# Altium schematic sheet [09] - Mechanical.SchDoc
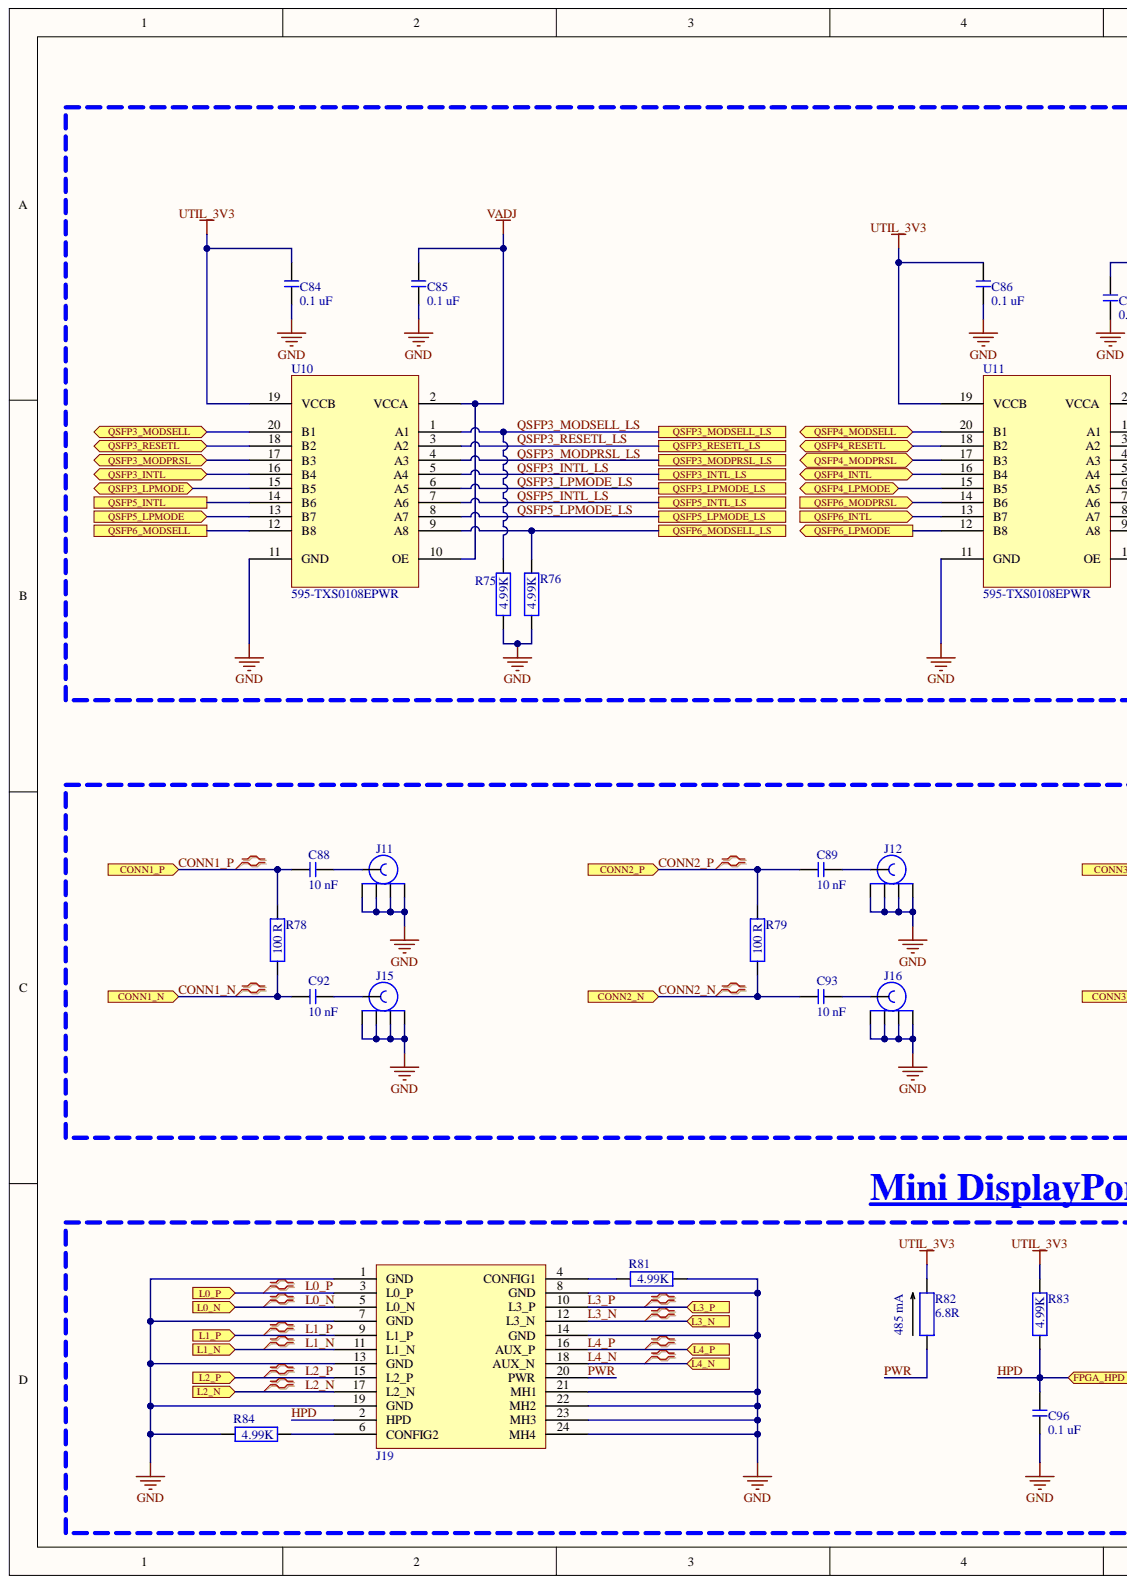
[diagram p0: [09] - Mechanical.SchDoc, left]
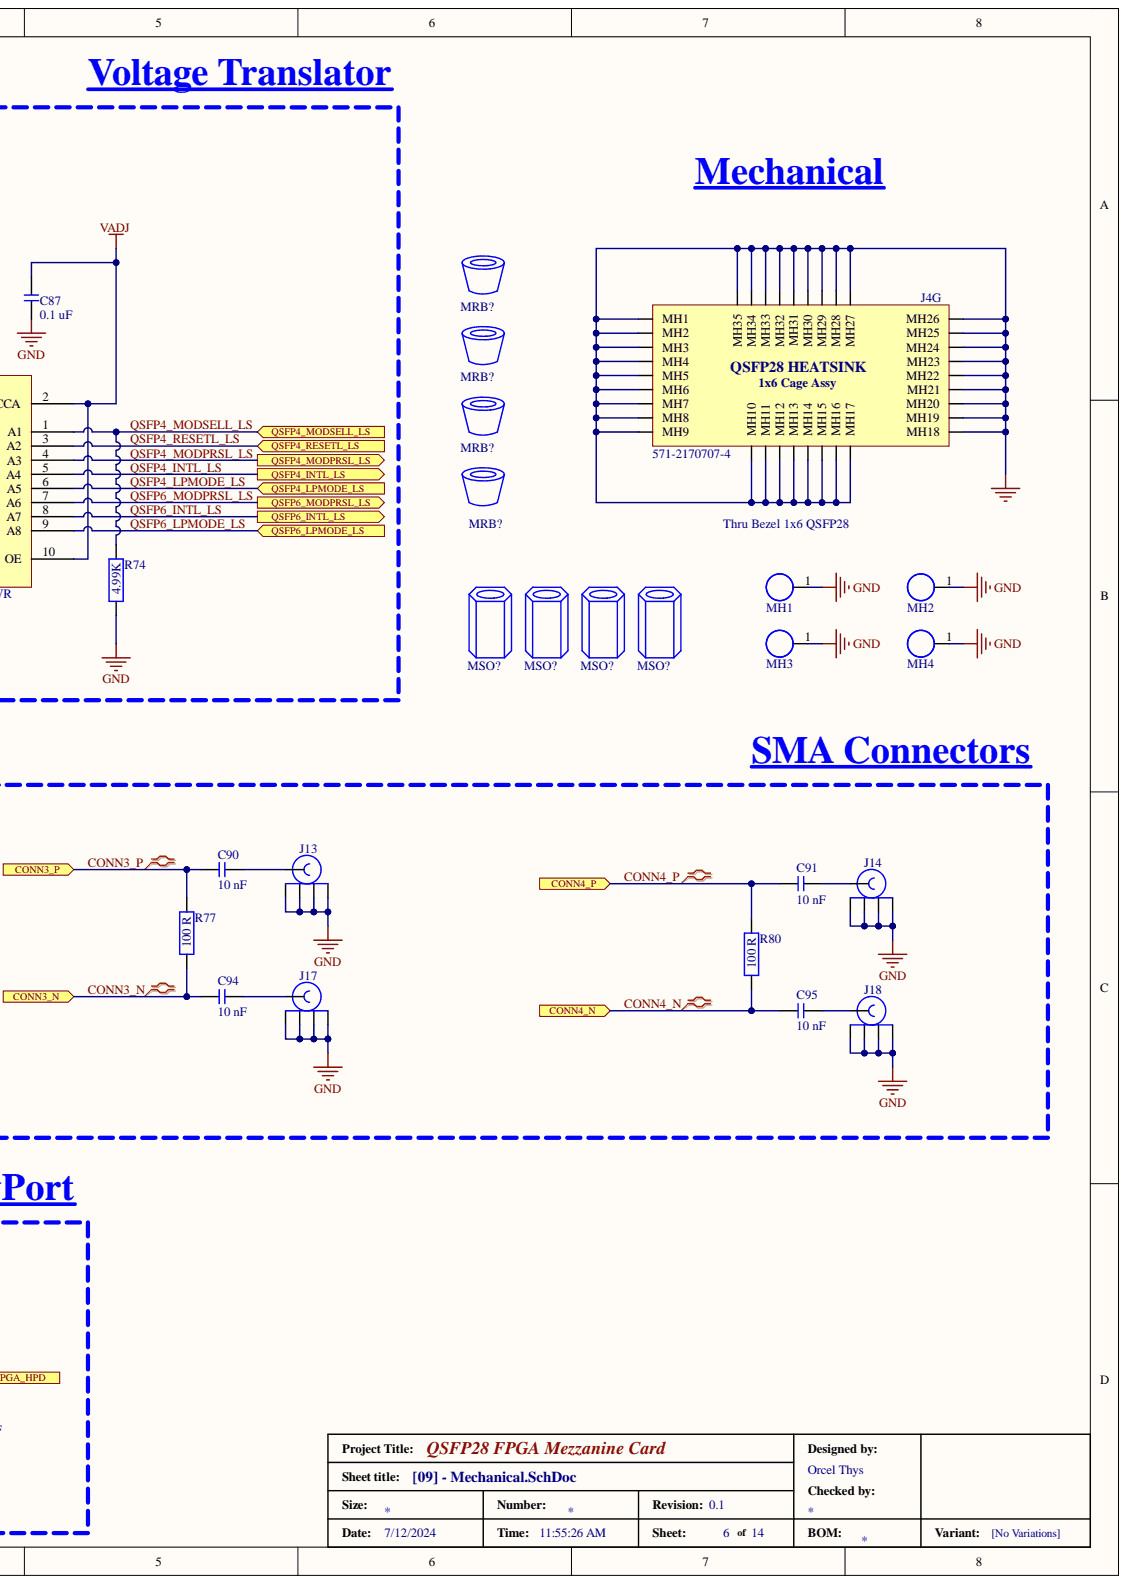
[diagram p1: [09] - Mechanical.SchDoc, right]

## Parts
C84  0.1 uF  [C0402]
C85  0.1 uF  [C0402]
C86  0.1 uF  [C0402]
C87  0.1 uF  [C0402]
C88  10 nF  [C0402]
C89  10 nF  [C0402]
C90  10 nF  [C0402]
C91  10 nF  [C0402]
C92  10 nF  [C0402]
C93  10 nF  [C0402]
C94  10 nF  [C0402]
C95  10 nF  [C0402]
C96  0.1 uF  [C0402]
J4  Thru Bezel 1x6 QSFP28  Supplier Part Number 1: 571-2170707-4  [TE_2170707-4]  (part G)
J11  SMA-J-P-H_ST-EM1  [SAMTEC_SMA-J-P-H-ST-EM1]  (value not drawn)
J12  SMA-J-P-H_ST-EM1  [SAMTEC_SMA-J-P-H-ST-EM1]  (value not drawn)
J13  SMA-J-P-H_ST-EM1  [SAMTEC_SMA-J-P-H-ST-EM1]  (value not drawn)
J14  SMA-J-P-H_ST-EM1  [SAMTEC_SMA-J-P-H-ST-EM1]  (value not drawn)
J15  SMA-J-P-H_ST-EM1  [SAMTEC_SMA-J-P-H-ST-EM1]  (value not drawn)
J16  SMA-J-P-H_ST-EM1  [SAMTEC_SMA-J-P-H-ST-EM1]  (value not drawn)
J17  SMA-J-P-H_ST-EM1  [SAMTEC_SMA-J-P-H-ST-EM1]  (value not drawn)
J18  SMA-J-P-H_ST-EM1  [SAMTEC_SMA-J-P-H-ST-EM1]  (value not drawn)
J19  Mini DisplayPort  [MiniDP_2129320-3]
MH1  Mountimg Hole  [MH_250H125]  (value not drawn)
MH2  Mountimg Hole  [MH_250H125]  (value not drawn)
MH3  Mountimg Hole  [MH_250H125]  (value not drawn)
MH4  Mountimg Hole  [MH_250H125]  (value not drawn)
R74  4.99K  [R0402]
R75  4.99K  [R0402]
R76  4.99K  [R0402]
R77  100 R  [R0402]
R78  100 R  [R0402]
R79  100 R  [R0402]
R80  100 R  [R0402]
R81  4.99K  [R0402]
R82  6.8R  [RESC6331X70N]
R83  4.99K  [R0402]
R84  4.99K  [R0402]
U10  Supplier Part Number 1: 595-TXS0108EPWR  [SOP_65P640X120-20N]  (hidden comment: 8-bit voltage translator)
U11  Supplier Part Number 1: 595-TXS0108EPWR  [SOP_65P640X120-20N]  (hidden comment: 8-bit voltage translator)

## Ports
CONN1_N, CONN1_P, CONN2_N, CONN2_P, CONN3_N, CONN3_P, CONN4_N, CONN4_P, FPGA_HPD, L0_N, L0_P, L1_N, L1_P, L2_N, L2_P, L3_N, L3_P, L4_N, L4_P, QSFP3_INTL, QSFP3_INTL_LS, QSFP3_LPMODE, QSFP3_LPMODE_LS, QSFP3_MODPRSL, QSFP3_MODPRSL_LS, QSFP3_MODSELL, QSFP3_MODSELL_LS, QSFP3_RESETL, QSFP3_RESETL_LS, QSFP4_INTL, QSFP4_INTL_LS, QSFP4_LPMODE, QSFP4_LPMODE_LS, QSFP4_MODPRSL, QSFP4_MODPRSL_LS, QSFP4_MODSELL, QSFP4_MODSELL_LS, QSFP4_RESETL, QSFP4_RESETL_LS, QSFP5_INTL, QSFP5_INTL_LS, QSFP5_LPMODE, QSFP5_LPMODE_LS, QSFP6_INTL, QSFP6_INTL_LS, QSFP6_LPMODE, QSFP6_LPMODE_LS, QSFP6_MODPRSL, QSFP6_MODPRSL_LS, QSFP6_MODSELL, QSFP6_MODSELL_LS

## Nets
GND: C84.1 C85.1 C86.1 C87.1 C96.1 J4.MH1 J4.MH2 J4.MH3 J4.MH4 J4.MH5 J4.MH6 J4.MH7 J4.MH8 J4.MH9 J4.MH10 J4.MH11 J4.MH12 J4.MH13 J4.MH14 J4.MH15 J4.MH16 J4.MH17 J4.MH18 J4.MH19 J4.MH20 J4.MH21 J4.MH22 J4.MH23 J4.MH24 J4.MH25 J4.MH26 J4.MH27 J4.MH28 J4.MH29 J4.MH30 J4.MH31 J4.MH32 J4.MH33 J4.MH34 J4.MH35 J11.2 J11.3 J11.4 J11.5 J12.2 J12.3 J12.4 J12.5 J13.2 J13.3 J13.4 J13.5 J14.2 J14.3 J14.4 J14.5 J15.2 J15.3 J15.4 J15.5 J16.2 J16.3 J16.4 J16.5 J17.2 J17.3 J17.4 J17.5 J18.2 J18.3 J18.4 J18.5 J19.1(GND) J19.7(GND) J19.8(GND) J19.13(GND) J19.14(GND) J19.19(GND) J19.21(MH1) J19.22(MH2) J19.23(MH3) J19.24(MH4) MH1.1 MH2.1 MH3.1 MH4.1 R74.1 R75.1 R76.1 R81.1 R84.1 U10.11(GND) U11.11(GND)
UTIL_3V3: C84.2 C86.2 R82.2 R83.2 U10.19(VCCB) U11.19(VCCB)
VADJ: C85.2 C87.2 U10.2(VCCA) U10.10(OE) U11.2(VCCA) U11.10(OE)
CONN1_N: C92.1 R78.1 ; port CONN1_N
CONN1_P: C88.1 R78.2 ; port CONN1_P
CONN2_N: C93.1 R79.1 ; port CONN2_N
CONN2_P: C89.1 R79.2 ; port CONN2_P
CONN3_N: C94.1 R77.1 ; port CONN3_N
CONN3_P: C90.2 R77.2 ; port CONN3_P
CONN4_N: C95.1 R80.1 ; port CONN4_N
CONN4_P: C91.1 R80.2 ; port CONN4_P
HPD: C96.2 J19.2(HPD) R83.1 ; port FPGA_HPD
L0_N: J19.5(L0_N) ; port L0_N
L0_P: J19.3(L0_P) ; port L0_P
L1_N: J19.11(L1_N) ; port L1_N
L1_P: J19.9(L1_P) ; port L1_P
L2_N: J19.17(L2_N) ; port L2_N
L2_P: J19.15(L2_P) ; port L2_P
L3_N: J19.12(L3_N) ; port L3_N
L3_P: J19.10(L3_P) ; port L3_P
L4_N: J19.18(AUX_N) ; port L4_N
L4_P: J19.16(AUX_P) ; port L4_P
PWR: J19.20(PWR) R82.1
QSFP3_INTL: U10.16(B4) ; port QSFP3_INTL
QSFP3_INTL_LS: U10.5(A4) ; port QSFP3_INTL_LS
QSFP3_LPMODE: U10.15(B5) ; port QSFP3_LPMODE
QSFP3_LPMODE_LS: U10.6(A5) ; port QSFP3_LPMODE_LS
QSFP3_MODPRSL: U10.17(B3) ; port QSFP3_MODPRSL
QSFP3_MODPRSL_LS: U10.4(A3) ; port QSFP3_MODPRSL_LS
QSFP3_MODSELL: U10.20(B1) ; port QSFP3_MODSELL
QSFP3_MODSELL_LS: R75.2 U10.1(A1) ; port QSFP3_MODSELL_LS
QSFP3_RESETL: U10.18(B2) ; port QSFP3_RESETL
QSFP3_RESETL_LS: U10.3(A2) ; port QSFP3_RESETL_LS
QSFP4_INTL: U11.16(B4) ; port QSFP4_INTL
QSFP4_INTL_LS: U11.5(A4) ; port QSFP4_INTL_LS
QSFP4_LPMODE: U11.15(B5) ; port QSFP4_LPMODE
QSFP4_LPMODE_LS: U11.6(A5) ; port QSFP4_LPMODE_LS
QSFP4_MODPRSL: U11.17(B3) ; port QSFP4_MODPRSL
QSFP4_MODPRSL_LS: U11.4(A3) ; port QSFP4_MODPRSL_LS
QSFP4_MODSELL: U11.20(B1) ; port QSFP4_MODSELL
QSFP4_MODSELL_LS: R74.2 U11.1(A1) ; port QSFP4_MODSELL_LS
QSFP4_RESETL: U11.18(B2) ; port QSFP4_RESETL
QSFP4_RESETL_LS: U11.3(A2) ; port QSFP4_RESETL_LS
QSFP5_INTL: U10.14(B6) ; port QSFP5_INTL
QSFP5_INTL_LS: U10.7(A6) ; port QSFP5_INTL_LS
QSFP5_LPMODE: U10.13(B7) ; port QSFP5_LPMODE
QSFP5_LPMODE_LS: U10.8(A7) ; port QSFP5_LPMODE_LS
QSFP6_INTL: U11.13(B7) ; port QSFP6_INTL
QSFP6_INTL_LS: U11.8(A7) ; port QSFP6_INTL_LS
QSFP6_LPMODE: U11.12(B8) ; port QSFP6_LPMODE
QSFP6_LPMODE_LS: U11.9(A8) ; port QSFP6_LPMODE_LS
QSFP6_MODPRSL: U11.14(B6) ; port QSFP6_MODPRSL
QSFP6_MODPRSL_LS: U11.7(A6) ; port QSFP6_MODPRSL_LS
QSFP6_MODSELL: U10.12(B8) ; port QSFP6_MODSELL
QSFP6_MODSELL_LS: R76.2 U10.9(A8) ; port QSFP6_MODSELL_LS
NetC88_2: C88.2 J11.1
NetC89_2: C89.2 J12.1
NetC90_1: C90.1 J13.1
NetC91_2: C91.2 J14.1
NetC92_2: C92.2 J15.1
NetC93_2: C93.2 J16.1
NetC94_2: C94.2 J17.1
NetC95_2: C95.2 J18.1
NetJ19_4: J19.4(CONFIG1) R81.2
NetJ19_6: J19.6(CONFIG2) R84.2

## Library data of the parts
C84-C87 C96 = UMK105B7104KV-FR: Cap 0402 0.1 uF 50 V ±10 % Tolerance X7R Surface Mount Multilayer Ceramic Capacitor; Manufacturer: Taiyo Yuden; Capacitance: 100nF; Tolerance: 10%; Voltage Rating: 50V; Case Code (Imperial): 0402; Case Code (Metric): 1005; Case/Package: 0402; Category: Ceramic Capacitors; Component Kind: Standard; ComponentLink1Description: https://datasheet.ciiva.com/24356/619umk105b7104kv-fr-24356845.pdf?src-supplier=Onlinecomponents.com; ComponentLink1URL: https://datasheet.ciiva.com/24356/619umk105b7104kv-fr-24356845.pdf?src-supplier=Onlinecomponents.com; ComponentLink2Description: https://datasheet.ciiva.com/30180/52umk105b7104kv-fr-30180098.pdf?src-supplier=Onlinecomponents.com; ComponentLink2URL: https://datasheet.ciiva.com/30180/52umk105b7104kv-fr-30180098.pdf?src-supplier=Onlinecomponents.com; ComponentLink3Description: https://datasheet.ciiva.com/18697/mlcc-all-e-18697452.pdf?src-supplier=Digi-Key; ComponentLink3URL: https://datasheet.ciiva.com/18697/mlcc-all-e-18697452.pdf?src-supplier=Digi-Key; ComponentLink4Description: https://datasheet.ciiva.com/18697/mlcc-all-e-18697451.pdf?src-supplier=Digi-Key; ComponentLink4URL: https://datasheet.ciiva.com/18697/mlcc-all-e-18697451.pdf?src-supplier=Digi-Key; ComponentLink5Description: https://datasheet.ciiva.com/18697/mlcc-all-e-18697453.pdf?src-supplier=Digi-Key; ComponentLink5URL: https://datasheet.ciiva.com/18697/mlcc-all-e-18697453.pdf?src-supplier=Digi-Key; Dielectric: X7R; Halogen Free: Halogen Free; Height: 0.5mm; Lead Free: Lead Free; Length: 0.039inch; Manufacturer Part Number: UMK105B7104KV-FR; Max Operating Temperature: 125°C; Min Operating Temperature: -55°C; Mount: Surface Mount; Package Quantity: 1; Packaging: Tape and Reel; Pricing 1: 10000=0.016 (EUR); RoHS: Yes; RoHS Compliant: Yes; Series: M; Stock 1: 60000; Supplier 1: Rs; Supplier Part Number 1: 1782869; Termination: SMD/SMT; Thickness: 0.022inch; Voltage Rating (DC): 50V; Width: 0.02inch; Library Name: QSFP28_FMC_lib.SchLib
C88-C95 = C0402C103K3RACTU: Cap Multilayer Ceramic Capacitors MLCC - SMD/SMT 25volts 10000pF X7R 10%; Manufacturer: KEMET; Capacitance: 10nF; Dissipation Factor: 3.5%; Voltage Rating: 25V; Case Code (Imperial): 0402; Case Code (Metric): 1005; Case/Package: 0402; Category: Ceramic Capacitors; Component Kind: Standard; ComponentLink1Description: https://datasheet.ciiva.com/7747/kem-c1002-x7r-smd-7747612.pdf?src-supplier=Element14; ComponentLink1URL: https://datasheet.ciiva.com/7747/kem-c1002-x7r-smd-7747612.pdf?src-supplier=Element14; ComponentLink2Description: https://datasheet.ciiva.com/45846/docfinderpartnumberc0402c102k5ractu-45846917.pdf?src-supplier=Onlinecomponents.com; ComponentLink2URL: https://datasheet.ciiva.com/45846/docfinderpartnumberc0402c102k5ractu-45846917.pdf?src-supplier=Onlinecomponents.com; ComponentLink3Description: https://datasheet.ciiva.com/18192/getdatasheetpartid-330802-18192396.pdf?src-supplier=Verical; ComponentLink3URL: https://datasheet.ciiva.com/18192/getdatasheetpartid-330802-18192396.pdf?src-supplier=Verical; Construction: Flat; Depth: 0.5mm; Dielectric: X7R; Height: 0.5mm; Lead Pitch: 0.3mm; Length: 1mm; Manufacturer Part Number: C0402C103K3RACTU; Max Operating Temperature: 125°C; Min Operating Temperature: -55°C; Mount: Surface Mount; Number of Pins: 2; Package Quantity: 10000; Packaging: Tape and Reel; Pricing 1: 1=0.1, 10=0.018, 50=0.018, 100=0.008, 1000=0.006, 10000=0.003 (USD); Radiation Hardening: No; Reach SVHC Compliant: No; RoHS: Yes; RoHS Compliant: Yes; Series: SMD Comm X7R; Stock 1: 1004059; Supplier 1: Mouser; Supplier Part Number 1: 80-C0402C103K3R; Termination: SMD/SMT; Tolerance: 10%; Voltage Rating (DC): 25V; Width: 0.5mm; Library Name: QSFP28_FMC_lib.SchLib
J4 = 2170707-4: Cage Assy, 1X6, QSFP28, Spring, Hs; Manufacturer: TE Connectivity AMP; Category: Connectors; Component Kind: Standard; ComponentLink1Description: https://www.te.com/commerce/DocumentDelivery/DDEController?Action=srchrtrv&DocNm=2170707&DocType=Customer+Drawing&DocLang=English&src-supplier=Digi-Key; ComponentLink1URL: https://www.te.com/commerce/DocumentDelivery/DDEController?Action=srchrtrv&DocNm=2170707&DocType=Customer+Drawing&DocLang=English&src-supplier=Digi-Key; Manufacturer Part Number: 2170707-4; Pricing 1: 1=80.77, 10=80.77, 50=71.27, 100=70.28, 1000=67.22, 10000=67.22 (USD); RoHS: Yes; Stock 1: 60; Supplier 1: Mouser; Library Name: QSFP28_FMC_lib.SchLib
J11-J18 = SMA-J-P-H-ST-EM1: RF Connectors / Coaxial Connectors 6 GHz, 50 Ohm SMA Jack or Plug, Cable Connector; Manufacturer: Samtec; Body Material: Brass; Body Plating: Gold; Dielectric Material: PTFE; Frequency: 20GHz; Impedance: 50R; Number of Contacts: 1NUMBER; Number of Ports: 1; Voltage Rating: 335V; Case/Package: SMA; Category: RF / Coaxial Connectors; Center Contact Plating: Gold; Component Kind: Standard; ComponentLink1Description: https://datasheet.ciiva.com/646/sma-j-p-x-st-em1-mkt-646053.pdf?src-supplier=Digikey; ComponentLink1URL: https://datasheet.ciiva.com/646/sma-j-p-x-st-em1-mkt-646053.pdf?src-supplier=Digikey; Connector Type: SMA; Contact Material: Copper; Contact Plating: Gold; Depth: 13.33mm; Fastening Type: Threaded; Housing Color: Gold; Insulation Material: Polytetrafluoroethylene; Length: 6.35mm; Manufacturer Part Number: SMA-J-P-H-ST-EM1; Max Frequency: 20GHz; Max Operating Temperature: 125°C; Min Operating Temperature: -65°C; Mount: Edge,Board; Orientation: Straight; Package Quantity: 1; Packaging: Tray; Pricing 1: 1=5.76, 10=4.18, 50=3.98, 100=3.83, 500=2.76 (EUR); Radiation Hardening: No; RoHS: Yes; RoHS Compliant: Yes; Series: -; Stock 1: 33; Supplier 1: Rs; Supplier Part Number 1: 1592304; Termination: Solder; Library Name: QSFP28_FMC_lib.SchLib
J19 = 47272-0029: Conn Display Port RCP 20 POS 1mm Solder RA SMD 20 Terminal 1 Port T/R; Manufacturer: Molex; Category: Audio / Video Connectors; Component Kind: Standard; ComponentLink1Description: http://www.molex.com/pdm_docs/sd/472720029_sd.pdf?src-supplier=Mouser; ComponentLink1URL: http://www.molex.com/pdm_docs/sd/472720029_sd.pdf?src-supplier=Mouser; Manufacturer Part Number: 47272-0029; Pricing 1: 1=5.3, 10=4.34, 100=3.83, 250=3.35, 500=3.26, 1000=2.77 (EUR); RoHS: Yes; Stock 1: 941; Supplier 1: Farnell; Supplier Part Number 1: 3911167; Library Name: QSFP28_FMC_lib.SchLib
MH1-MH4 = MH_250H125: MOUNTING HOLE, 125 HOLE 250 PAD; Component Kind: Standard; Library Name: QSFP28_FMC_lib.SchLib
R74-R76 R81 R83 R84 = ERJ-2RKF4991X: RES SMD 4.99K OHM 1% 1/10W 0402; Manufacturer: Panasonic; Max Power Dissipation: 100mW; Resistance: 4.99kR; Temperature Coefficient: 100ppm/°C; Voltage Rating: 50V; Case Code (Imperial): 0402; Case Code (Metric): 1005; Case/Package: 0402; Category: Chip SMD Resistors; Component Kind: Standard; ComponentLink1Description: https://datasheet.ciiva.com/46388/426256-46388311.pdf?src-supplier=Element14; ComponentLink1URL: https://datasheet.ciiva.com/46388/426256-46388311.pdf?src-supplier=Element14; ComponentLink2Description: https://datasheet.ciiva.com/46376/426256-46376955.pdf?src-supplier=Element14; ComponentLink2URL: https://datasheet.ciiva.com/46376/426256-46376955.pdf?src-supplier=Element14; ComponentLink3Description: https://datasheet.ciiva.com/22749/1678782-22749654.pdf?src-supplier=Element14; ComponentLink3URL: https://datasheet.ciiva.com/22749/1678782-22749654.pdf?src-supplier=Element14; ComponentLink4Description: https://datasheet.ciiva.com/24158/aoa0000ce2-24158871.pdf?src-supplier=Mouser; ComponentLink4URL: https://datasheet.ciiva.com/24158/aoa0000ce2-24158871.pdf?src-supplier=Mouser; ComponentLink5Description: https://datasheet.ciiva.com/16775/70260748-16775018.pdf?src-supplier=Allied+Electronics; ComponentLink5URL: https://datasheet.ciiva.com/16775/70260748-16775018.pdf?src-supplier=Allied+Electronics; ComponentLink6Description: https://datasheet.ciiva.com/16773/70260748-16773110.pdf?src-supplier=Allied+Electronics; ComponentLink6URL: https://datasheet.ciiva.com/16773/70260748-16773110.pdf?src-supplier=Allied+Electronics; ComponentLink7Description: https://datasheet.ciiva.com/16199/aoa0000ce2-16199546.pdf?src-supplier=Future+Electronics; ComponentLink7URL: https://datasheet.ciiva.com/16199/aoa0000ce2-16199546.pdf?src-supplier=Future+Electronics; Construction: Rectangular; Contact Plating: Tin; Depth: 0.5mm; Features: Automotive AEC-Q200; Height: 0.016inch; Lead Free: Lead Free; Length: 1mm; Manufacturer Part Number: ERJ-2RKF4991X; Max Operating Temperature: 155°C; Military Standard: Not; Min Operating Temperature: -55°C; Mount: Surface Mount; Number of Terminations: 2; Package Quantity: 1; Packaging: Tape and Reel; Power Rating: 100mW; Pricing 1: 1=0.1, 10=0.018, 50=0.018, 100=0.008, 1000=0.006, 10000=0.004 (USD); Radiation Hardening: No; Reach SVHC Compliant: No; Resistance Tolerance: 1%; Resistor Type: Thick Film; RoHS: Yes; RoHS Compliant: Yes; Series: ERJ; Stock 1: 1218727; Supplier 1: Mouser; Supplier Part Number 1: 667-ERJ-2RKF4991X; Technology: THICK FILM; Termination: SMD/SMT; Tolerance: 1%; Voltage Rating (DC): 50V; Weight: 2.8E-05oz; Width: 0.5mm; Working Voltage: 50V; Library Name: QSFP28_FMC_lib.SchLib
R77-R80 = ERJ-2RKF1000X: RES Thick Film 0402 100 Ohm 1% 1/10W 100ppm/C Molded SMD Punched Carrier T/R; Manufacturer: Panasonic; Max Power Dissipation: 100mW; Resistance: 100R; Temperature Coefficient: 100ppm/°C; Voltage Rating: 50V; Case Code (Imperial): 0402; Case Code (Metric): 1005; Case/Package: 0402; Category: Chip SMD Resistors; Component Kind: Standard; ComponentLink1Description: https://datasheet.ciiva.com/27056/2007311-27056035.pdf?src-supplier=Jameco; ComponentLink1URL: https://datasheet.ciiva.com/27056/2007311-27056035.pdf?src-supplier=Jameco; ComponentLink2Description: https://datasheet.ciiva.com/11821/0900766b80f80784-11821173.pdf?src-supplier=Rs; ComponentLink2URL: https://datasheet.ciiva.com/11821/0900766b80f80784-11821173.pdf?src-supplier=Rs; ComponentLink3Description: https://datasheet.ciiva.com/7830/426256-7830973.pdf?src-supplier=Element14; ComponentLink3URL: https://datasheet.ciiva.com/7830/426256-7830973.pdf?src-supplier=Element14; ComponentLink4Description: https://datasheet.ciiva.com/43467/1678782-43467223.pdf?src-supplier=Farnell; ComponentLink4URL: https://datasheet.ciiva.com/43467/1678782-43467223.pdf?src-supplier=Farnell; ComponentLink5Description: https://datasheet.ciiva.com/28514/1678782-28514175.pdf?src-supplier=Element14; ComponentLink5URL: https://datasheet.ciiva.com/28514/1678782-28514175.pdf?src-supplier=Element14; ComponentLink6Description: https://datasheet.ciiva.com/46372/1678782-46372741.pdf?src-supplier=Element14; ComponentLink6URL: https://datasheet.ciiva.com/46372/1678782-46372741.pdf?src-supplier=Element14; ComponentLink7Description: https://datasheet.ciiva.com/16776/70260748-16776427.pdf?src-supplier=Allied+Electronics; ComponentLink7URL: https://datasheet.ciiva.com/16776/70260748-16776427.pdf?src-supplier=Allied+Electronics; ComponentLink8Description: https://datasheet.ciiva.com/9186/aoa0000ce2-9186683.pdf?src-supplier=Avnet; ComponentLink8URL: https://datasheet.ciiva.com/9186/aoa0000ce2-9186683.pdf?src-supplier=Avnet; ComponentLink9Description: https://datasheet.ciiva.com/16199/aoa0000ce2-16199575.pdf?src-supplier=Future+Electronics; ComponentLink9URL: https://datasheet.ciiva.com/16199/aoa0000ce2-16199575.pdf?src-supplier=Future+Electronics; ComponentLink10Description: https://datasheet.ciiva.com/48531/aoa0000ce2-48531067.pdf?src-supplier=Avnet; ComponentLink10URL: https://datasheet.ciiva.com/48531/aoa0000ce2-48531067.pdf?src-supplier=Avnet; ComponentLink11Description: https://datasheet.ciiva.com/30260/aoa0000ce2-30260809.pdf?src-supplier=Onlinecomponents.com; ComponentLink11URL: https://datasheet.ciiva.com/30260/aoa0000ce2-30260809.pdf?src-supplier=Onlinecomponents.com; Construction: Rectangular; Contact Plating: Tin; Depth: 0.5mm; Features: Automotive AEC-Q200; Height: 0.35mm; Lead Free: Lead Free; Length: 1mm; Manufacturer Part Number: ERJ-2RKF1000X; Max Operating Temperature: 155°C; Military Standard: Not; Min Operating Temperature: -55°C; Mount: Surface Mount; Number of Pins: 2; Number of Terminations: 2; Package Quantity: 1; Packaging: Tape and Reel; Power Rating: 100mW; Pricing 1: 1=0.1, 10=0.017, 50=0.017, 100=0.008, 1000=0.006, 10000=0.004 (USD); Radiation Hardening: No; Reach SVHC Compliant: No; Resistance Tolerance: 1%; Resistor Type: Thick Film; RoHS: Yes; RoHS Compliant: Yes; Series: ERJ; Size Code: 0402; Stock 1: 11989335; Supplier 1: Mouser; Supplier Part Number 1: 667-ERJ-2RKF1000X; Technology: THICK FILM; Termination: SMD/SMT; Tolerance: 1%; Voltage Rating (DC): 50V; Width: 0.5mm; Library Name: QSFP28_FMC_lib.SchLib
R82 = CRCW25126R80FKEGHP: SMD Chip Resistor, 6.8 Ohm, ± 1%, 1.5 W, 2512 [6332 Metric], Thick Film, High Power Pulse Proof; Manufacturer: Vishay Dale; Category: Chip SMD Resistors; Component Kind: Standard; ComponentLink1Description: https://datasheet.ciiva.com/2615/crcwhpe3-2615657.pdf?src-supplier=Digikey; ComponentLink1URL: https://datasheet.ciiva.com/2615/crcwhpe3-2615657.pdf?src-supplier=Digikey; ComponentLink2Description: https://datasheet.ciiva.com/9060/crcwhpe3-9060927.pdf?src-supplier=Avnet; ComponentLink2URL: https://datasheet.ciiva.com/9060/crcwhpe3-9060927.pdf?src-supplier=Avnet; Manufacturer Part Number: CRCW25126R80FKEGHP; Pricing 1: 5=0.533, 50=0.327, 250=0.305, 500=0.282, 1000=0.277, 2000=0.273, 10000=0.268, 20000=0.263 (EUR); RoHS: Yes; Stock 1: 1935; Supplier 1: Farnell; Supplier Part Number 1: 3546537; Library Name: QSFP28_FMC_lib.SchLib
U10 U11 = TXS0108EPWR: IC 8BIT NON-INV TRANSLTR 20TSSOP; Manufacturer: Texas Instruments; Channel Type: Bidirectional; Data Rate: 60Mbps; High Level Output Current: -50mA; Low Level Output Current: 50mA; Max Supply Voltage: 5.5V; Min Supply Voltage: 1.2V; Number of Bits: 8; Number of Circuits: 1; Output Current: 620uA; Propagation Delay: 4.8ns; Quiescent Current: 3uA; Rise Time: 6.3ns; Supply Current: 8uA; Turn-On Delay Time: 312ns; Case/Package: TSSOP; Category: Voltage Level Shifters; Component Kind: Standard; ComponentLink1Description: https://datasheet.ciiva.com/14287/txs0108e-14287280.pdf?src-supplier=Farnell; ComponentLink1URL: https://datasheet.ciiva.com/14287/txs0108e-14287280.pdf?src-supplier=Farnell; ComponentLink2Description: https://datasheet.ciiva.com/28653/1714661-28653429.pdf?src-supplier=Element14; ComponentLink2URL: https://datasheet.ciiva.com/28653/1714661-28653429.pdf?src-supplier=Element14; ComponentLink3Description: https://datasheet.ciiva.com/4834/sces642b-127094-4834401.pdf?src-supplier=Mouser; ComponentLink3URL: https://datasheet.ciiva.com/4834/sces642b-127094-4834401.pdf?src-supplier=Mouser; ComponentLink4Description: https://datasheet.ciiva.com/15096/getdatasheetpartid-322119-15096276.pdf?src-supplier=Verical; ComponentLink4URL: https://datasheet.ciiva.com/15096/getdatasheetpartid-322119-15096276.pdf?src-supplier=Verical; ComponentLink5Description: https://datasheet.ciiva.com/1508/1815244-1508732.pdf?src-supplier=Element14; ComponentLink5URL: https://datasheet.ciiva.com/1508/1815244-1508732.pdf?src-supplier=Element14; ComponentLink6Description: https://datasheet.ciiva.com/1899/txs0108e-1899605.pdf?src-supplier=Digi-Key; ComponentLink6URL: https://datasheet.ciiva.com/1899/txs0108e-1899605.pdf?src-supplier=Digi-Key; ComponentLink7Description: https://datasheet.ciiva.com/1899/txs0108e-1899604.pdf?src-supplier=Digi-Key; ComponentLink7URL: https://datasheet.ciiva.com/1899/txs0108e-1899604.pdf?src-supplier=Digi-Key; ComponentLink8Description: https://datasheet.ciiva.com/1899/txs0108e-1899606.pdf?src-supplier=Digi-Key; ComponentLink8URL: https://datasheet.ciiva.com/1899/txs0108e-1899606.pdf?src-supplier=Digi-Key; ComponentLink9Description: https://datasheet.ciiva.com/14287/txs0108e-14287532.pdf?src-supplier=Avnet; ComponentLink9URL: https://datasheet.ciiva.com/14287/txs0108e-14287532.pdf?src-supplier=Avnet; Lead Free: Lead Free; Logic Function: Translator; Manufacturer Part Number: TXS0108EPWR; Max Operating Temperature: 85°C; Min Operating Temperature: -40°C; Mount: Surface Mount; Number of Channels: 8; Number of Inputs: 8; Number of Pins: 20; Package Quantity: 2000; Packaging: Tape and Reel; Pricing 1: 1=1.57, 10=1.41, 50=1.33, 100=1.13, 1000=0.772, 10000=0.702 (USD); Radiation Hardening: No; Reach SVHC Compliant: No; RoHS: Yes; RoHS Compliant: Yes; Series: -; Stock 1: 220045; Supplier 1: Mouser; Termination: SMD/SMT; Weight: 0.002716oz; Library Name: FmcLib.SchLib
